# Altium schematic sheet Sheet1.SchDoc
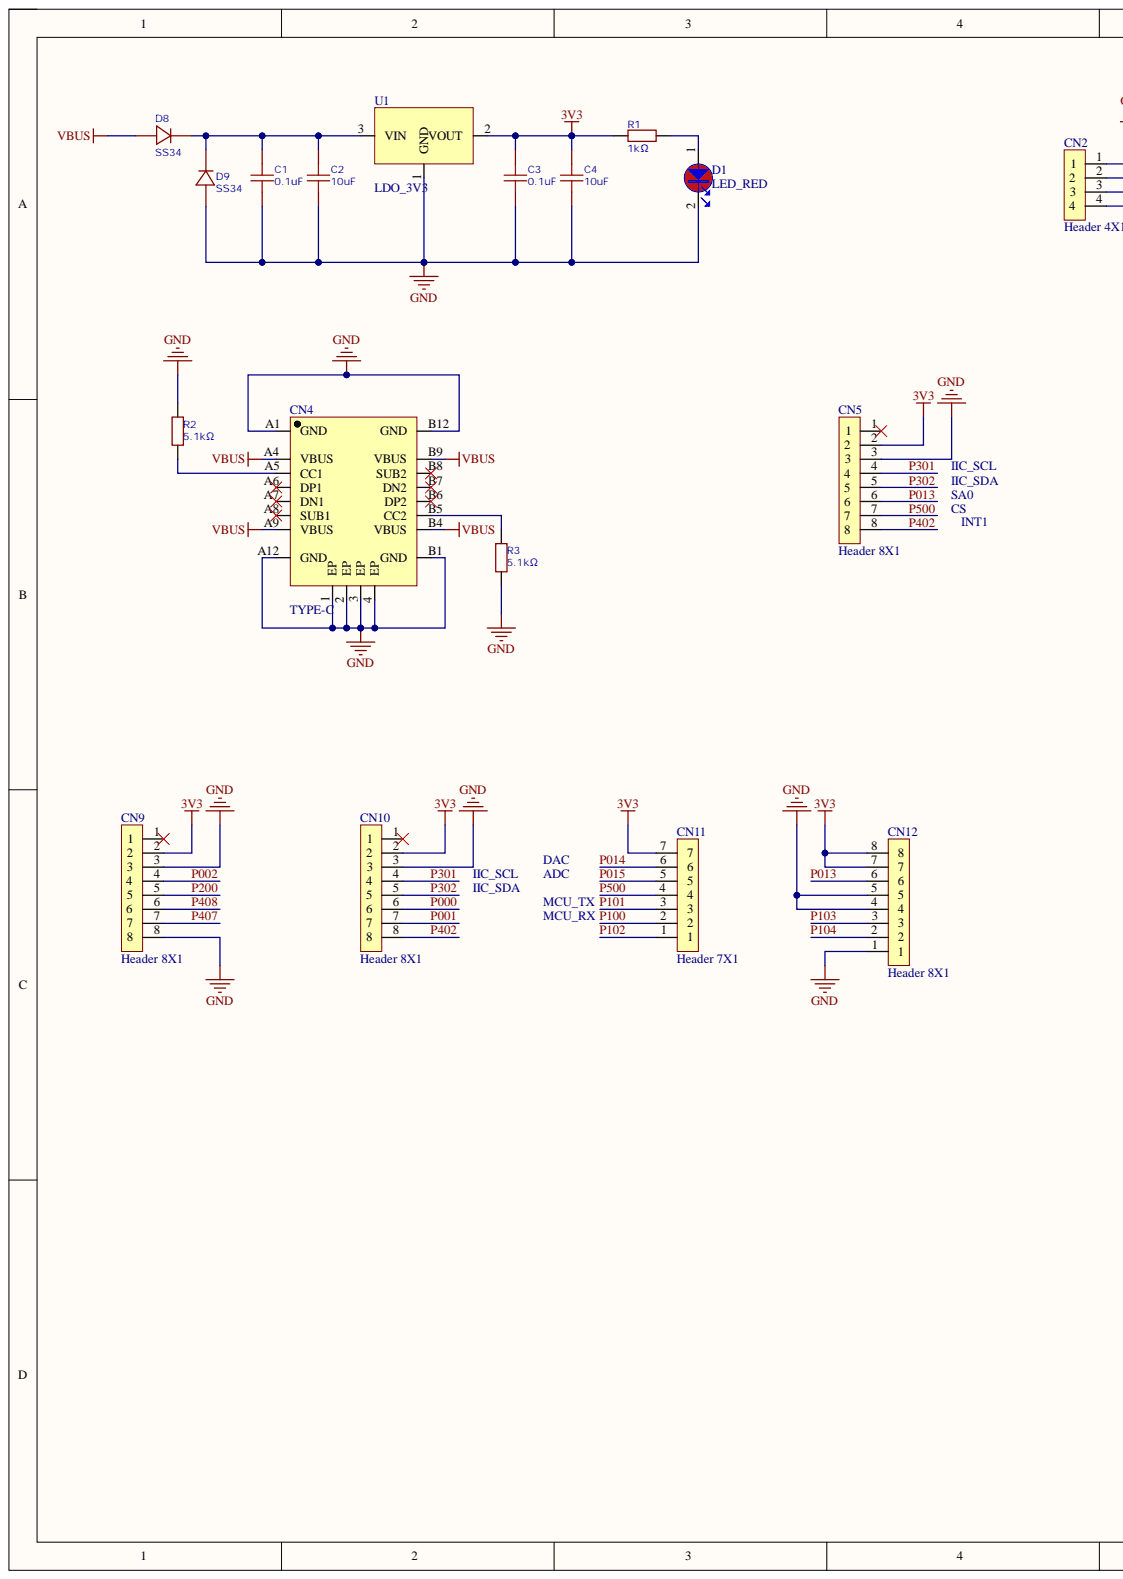
[diagram p0: Sheet1.SchDoc, left]
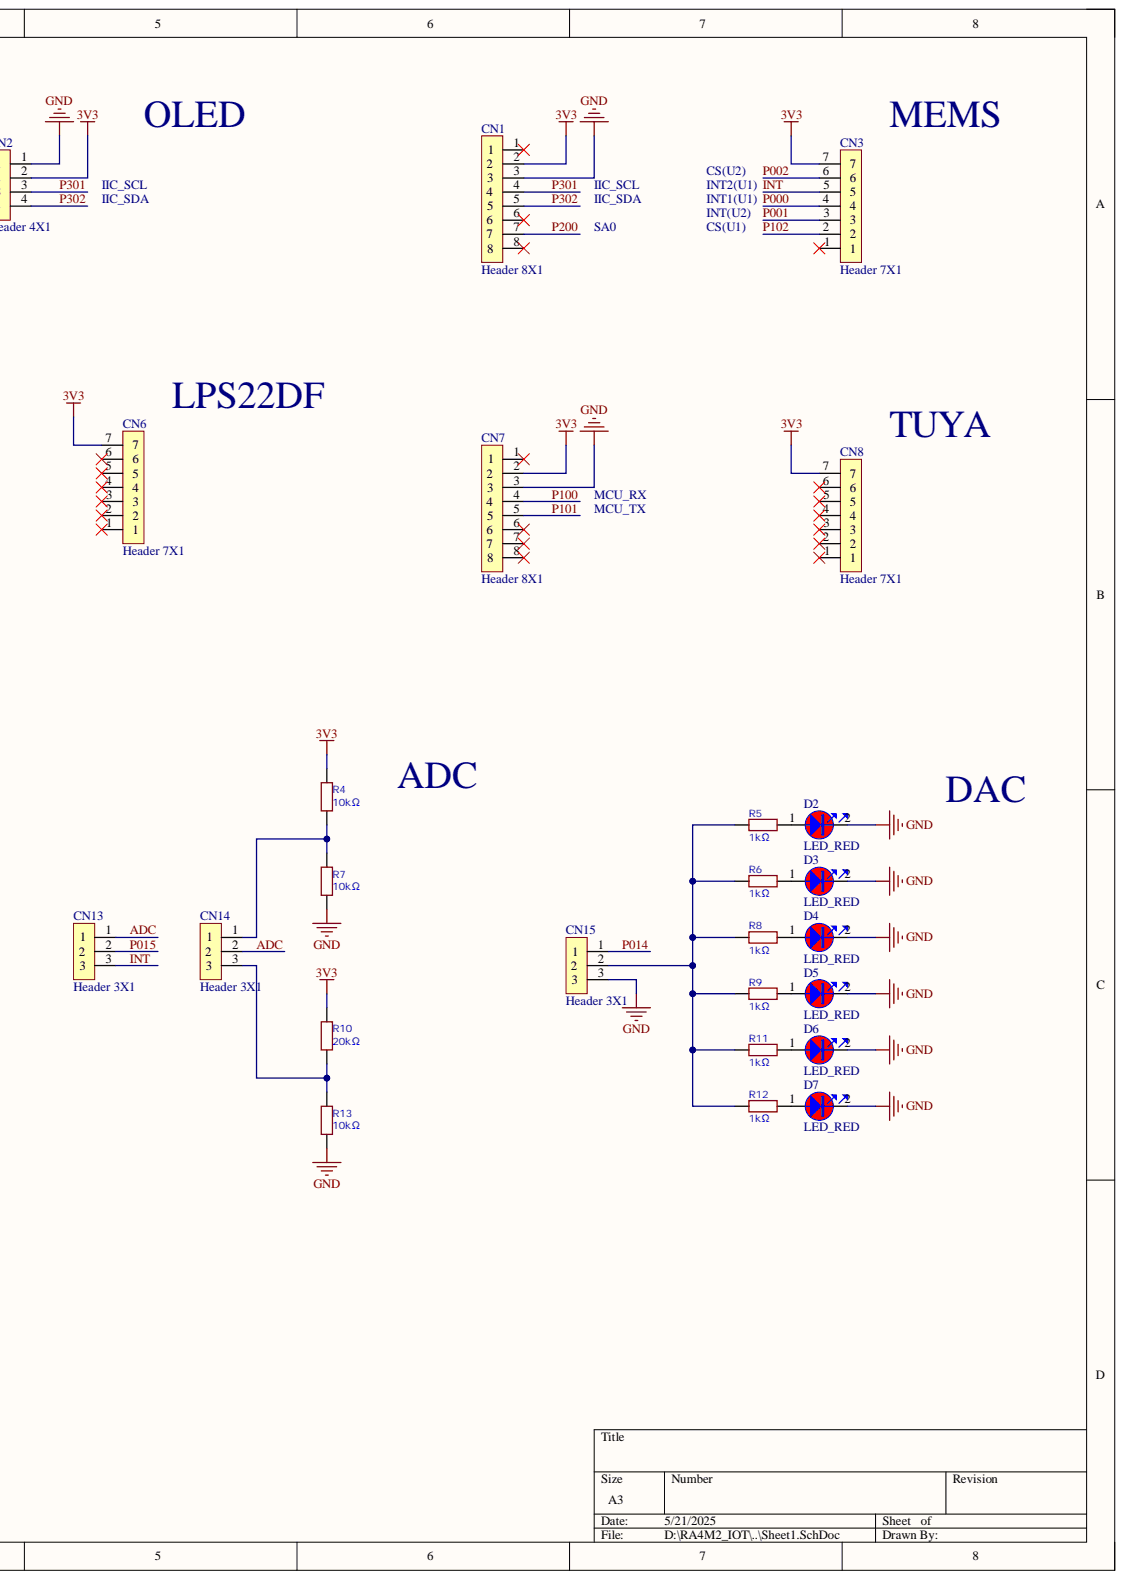
[diagram p1: Sheet1.SchDoc, right]

## Parts
C1  0.1uF  [C0603]
C2  10uF  [C0603]
C3  0.1uF  [C0603]
C4  10uF  [C0603]
CN1  Header 8X1  [1X8PIN]
CN2  Header 4X1  [1X4PIN]
CN3  Header 7X1  [1X7PIN]
CN4  TYPE-C  [TYPE-C-16P]
CN5  Header 8X1  [1X8PIN]
CN6  Header 7X1  [1X7PIN]
CN7  Header 8X1  [1X8PIN]
CN8  Header 7X1  [1X7PIN]
CN9  Header 8X1  [1X8PIN]
CN10  Header 8X1  [1X8PIN]
CN11  Header 7X1  [1X7PIN]
CN12  Header 8X1  [1X8PIN]
CN13  Header 3X1  [1X3PIN]
CN14  Header 3X1  [1X3PIN]
CN15  Header 3X1  [1X3PIN]
D1  LED_RED  [LED-RED]
D2  LED_RED  [LED-RED]
D3  LED_RED  [LED-RED]
D4  LED_RED  [LED-RED]
D5  LED_RED  [LED-RED]
D6  LED_RED  [LED-RED]
D7  LED_RED  [LED-RED]
D8  SS34  [SMA_L4.4-W2.6]
D9  SS34  [SMA_L4.4-W2.6]
R1  1kΩ  [R0603]
R2  5.1kΩ  [R0603]
R3  5.1kΩ  [R0603]
R4  10kΩ  [R0603]
R5  1kΩ  [R0603]
R6  1kΩ  [R0603]
R7  10kΩ  [R0603]
R8  1kΩ  [R0603]
R9  1kΩ  [R0603]
R10  20kΩ  [R0603]
R11  1kΩ  [R0603]
R12  1kΩ  [R0603]
R13  10kΩ  [R0603]
U1  LDO_3V3  [SOT223]

## Nets
3V3: C3.2 C4.2 CN1.2 CN2.2 CN3.7 CN5.2 CN6.7 CN7.2 CN8.7 CN9.2 CN10.2 CN11.7 CN12.7 CN12.8 R1.1 R4.2 R10.2 U1.2
GND: C1.1 C2.1 C3.1 C4.1 CN1.3 CN2.1 CN4.1(EP) CN4.2(EP) CN4.3(EP) CN4.4(EP) CN4.A1(GND) CN4.A12(GND) CN4.B1(GND) CN4.B12(GND) CN5.3 CN7.3 CN9.3 CN9.8 CN10.3 CN12.1 CN12.4 CN12.5 CN15.3 D1.2 D2.2 D3.2 D4.2 D5.2 D6.2 D7.2 D9.2 R2.2 R3.1 R7.1 R13.1 U1.1
VBUS: CN4.A4(VBUS) CN4.A9(VBUS) CN4.B4(VBUS) CN4.B9(VBUS) D8.2
ADC: CN13.1 CN14.2
INT: CN3.5 CN13.3
P000: CN3.4 CN10.6
P001: CN3.3 CN10.7
P002: CN3.6 CN9.4
P013: CN5.6 CN12.6
P014: CN11.6 CN15.1
P015: CN11.5 CN13.2
P100: CN7.4 CN11.2
P101: CN7.5 CN11.3
P102: CN3.2 CN11.1
P103: CN12.3
P104: CN12.2
P200: CN1.7 CN9.5
P301: CN1.4 CN2.3 CN5.4 CN10.4
P302: CN1.5 CN2.4 CN5.5 CN10.5
P402: CN5.8 CN10.8
P407: CN9.7
P408: CN9.6
P500: CN5.7 CN11.4
NetC1_2: C1.2 C2.2 D8.1 D9.1 U1.3
NetCN4_A5: CN4.A5(CC1) R2.1
NetCN4_B5: CN4.B5(CC2) R3.2
NetCN14_1: CN14.1 R4.1 R7.2
NetCN14_3: CN14.3 R10.1 R13.2
NetCN15_2: CN15.2 R5.1 R6.1 R8.1 R9.1 R11.1 R12.1
NetD1_1: D1.1 R1.2
NetD2_1: D2.1 R5.2
NetD3_1: D3.1 R6.2
NetD4_1: D4.1 R8.2
NetD5_1: D5.1 R9.2
NetD6_1: D6.1 R11.2
NetD7_1: D7.1 R12.2

Not connected: CN1 1 6 8; CN3 1; CN4 A6(DP1) A7(DN1) A8(SUB1) B6(DP2) B7(DN2) B8(SUB2); CN5 1; CN6 1 2 3 4 5 6; CN7 1 6 7 8; CN8 1 2 3 4 5 6; CN9 1; CN10 1

## Library data of the parts
C1-C4 = CAP: Component Kind: Standard; Description: CAP; Library Name: Schlib1.SchLib
R1-R13 = Res: Component Kind: Standard; Description: Res; Library Name: Schlib1.SchLib
CN1 CN5 CN7 CN9 CN10 CN12 = Header 8X1: Component Kind: Standard; Description: Header 8X1; Library Name: Schlib1.SchLib
CN2 = Header 4X1: Component Kind: Standard; Description: Header 4X1; Library Name: Schlib1.SchLib
CN3 CN6 CN8 CN11 = Header 7X1: Component Kind: Standard; Description: Header 7X1; Library Name: Schlib1.SchLib
CN4 = TYPE-C: Component Kind: Standard; Description: TYPE-C; Library Name: Schlib1.SchLib
CN13-CN15 = Header 3X1: Component Kind: Standard; Description: Header 3X1; Library Name: Schlib1.SchLib
D1-D7 = LED_RED: Component Kind: Standard; Description: LED_RED; Library Name: Schlib1.SchLib
D8 D9 = SS34: Component Kind: Standard; Description: SS34; Library Name: Schlib1.SchLib
U1 = LDO_3V3: Component Kind: Standard; Description: LDO_3V3; Library Name: Schlib1.SchLib
